# Altium schematic sheet MCU.SchDoc(MCU)
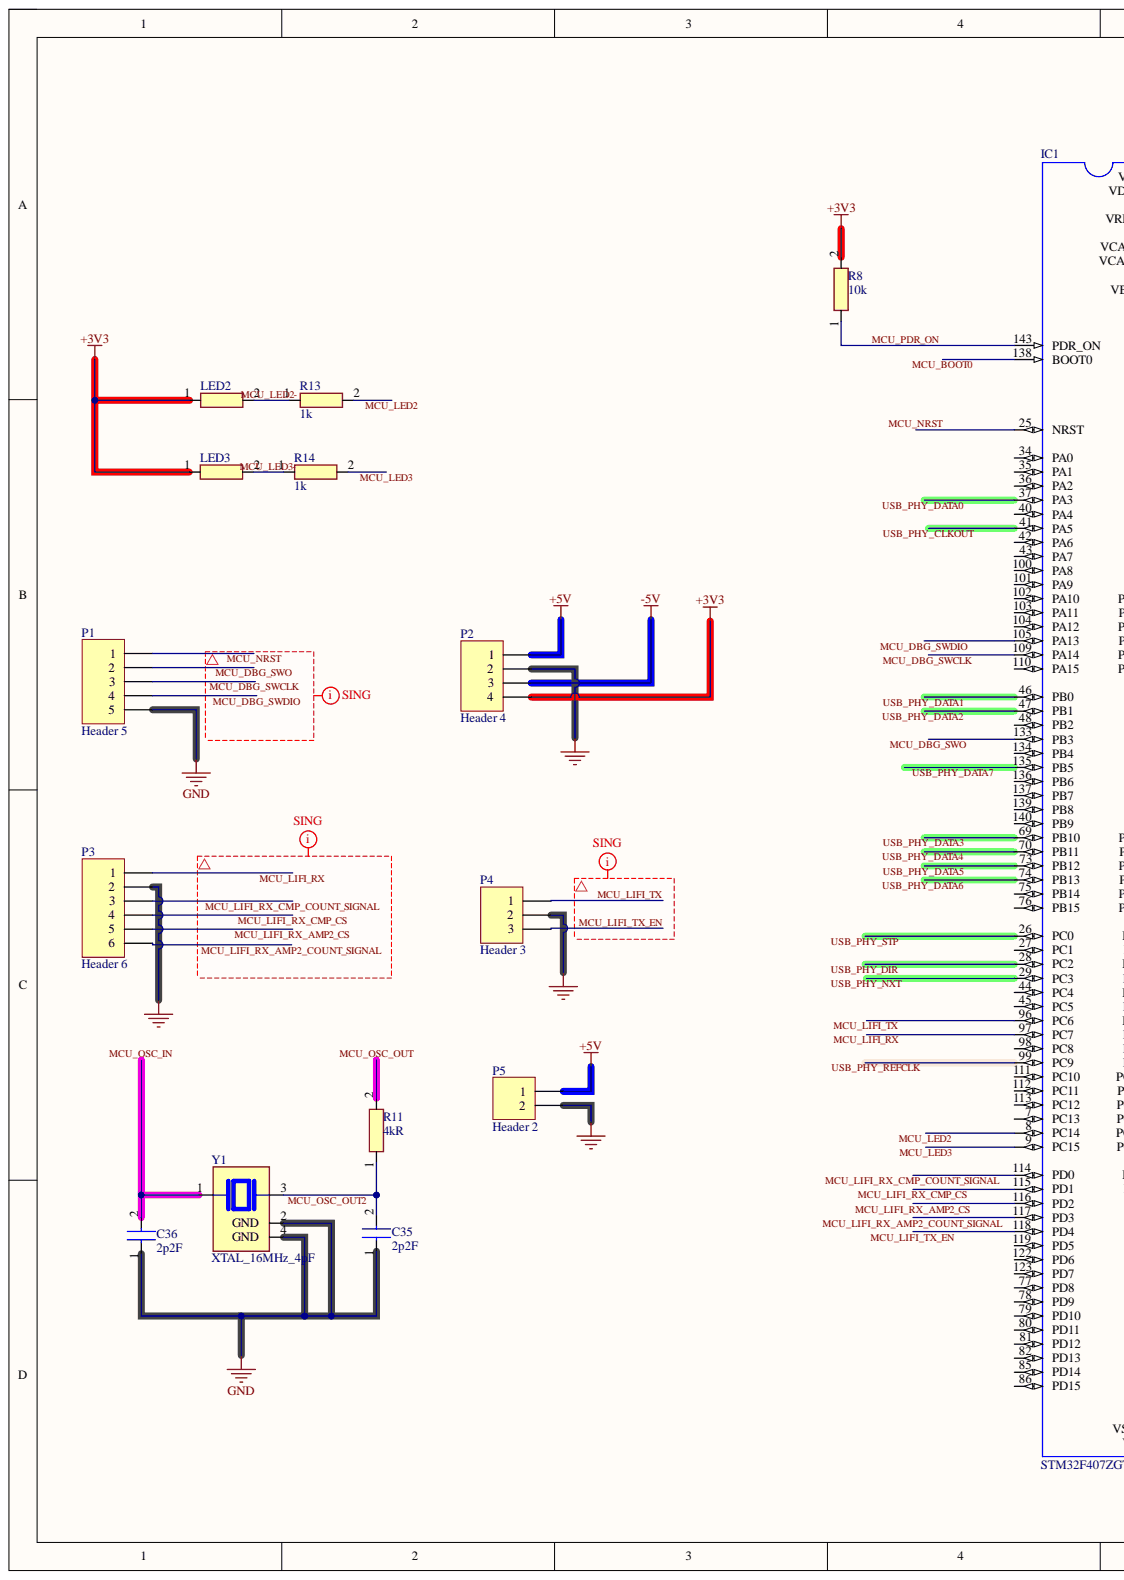
[diagram p0: MCU.SchDoc, left]
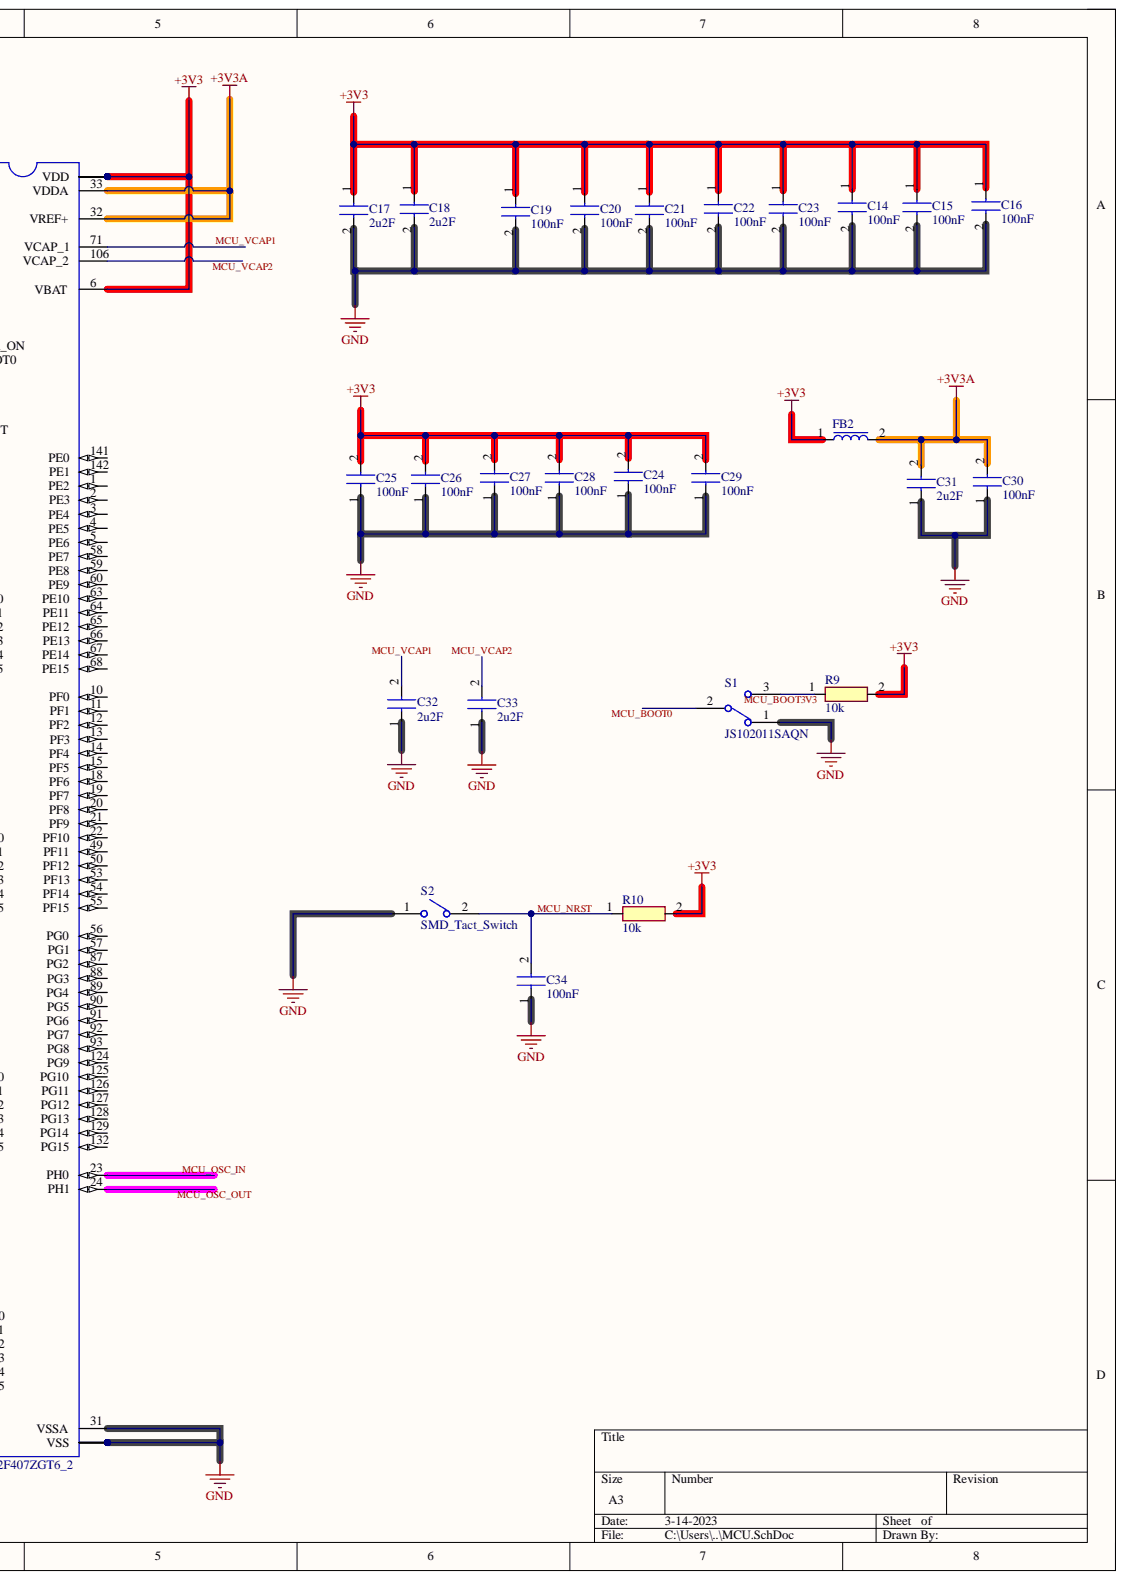
[diagram p1: MCU.SchDoc, right]

## Parts
C14  100nF  [0603C]
C15  100nF  [0603C]
C16  100nF  [0603C]
C17  2u2F  [0603C]
C18  2u2F  [0603C]
C19  100nF  [0603C]
C20  100nF  [0603C]
C21  100nF  [0603C]
C22  100nF  [0603C]
C23  100nF  [0603C]
C24  100nF  [0603C]
C25  100nF  [0603C]
C26  100nF  [0603C]
C27  100nF  [0603C]
C28  100nF  [0603C]
C29  100nF  [0603C]
C30  100nF  [0603C]
C31  2u2F  [0603C]
C32  2u2F  [0603C]
C33  2u2F  [0603C]
C34  100nF  [0603C]
C35  2p2F  [0603C]
C36  2p2F  [0603C]
FB2  Ferrite Bead @100R  [BEADC1608X90N]  (value not drawn)
IC1  STM32F407ZGT6_2  [STM32F407ZGT6]
LED2  1k  [0603R]  (value not drawn)
LED3  1k  [0603R]  (value not drawn)
P1  Header 5  [HDR1X5]
P2  Header 4  [HDR1X4]
P3  Header 6  [HDR1X6]
P4  Header 3  [HDR1X3]
P5  Header 2  [HDR1X2]
R8  10k  [0603R]
R9  10k  [0603R]
R10  10k  [0603R]
R11  4kR  [0603R]
R13  1k  [0603R]
R14  1k  [0603R]
S1  JS102011SAQN  [SW_JS102011SAQN]
S2  SMD_Tact_Switch  [SMD_Tact_Switch]
Y1  XTAL_16MHz_4pF  [XTAL_16.0000MHZ_4PF]

## Nets
+3V3: C14.1 C15.1 C16.1 C17.1 C18.1 C19.1 C20.1 C21.1 C22.1 C23.1 C24.2 C25.2 C26.2 C27.2 C28.2 C29.2 FB2.1 IC1.6(VBAT) IC1.17(VDD) IC1.30 IC1.39 IC1.52 IC1.62 IC1.72 IC1.84 IC1.95 IC1.108 IC1.121 IC1.131 IC1.144 LED2.1 LED3.1 P2.4 R8.2 R9.2 R10.2
+3V3A: C30.2 C31.2 FB2.2 IC1.32(VREF+) IC1.33(VDDA)
+5V: P2.1 P5.1
-5V: P2.3
GND: C14.2 C15.2 C16.2 C17.2 C18.2 C19.2 C20.2 C21.2 C22.2 C23.2 C24.1 C25.1 C26.1 C27.1 C28.1 C29.1 C30.1 C31.1 C32.1 C33.1 C34.1 C35.1 C36.1 IC1.16(VSS) IC1.31(VSSA) IC1.38 IC1.51 IC1.61 IC1.83 IC1.94 IC1.107 IC1.120 IC1.130 P1.5 P2.2 P3.2 P4.2 P5.2 S1.1 S2.1 Y1.2(GND) Y1.4(GND)
MCU_BOOT0: IC1.138(BOOT0) S1.2
MCU_BOOT3V3: R9.1 S1.3
MCU_DBG_SWCLK: IC1.109(PA14) P1.3
MCU_DBG_SWDIO: IC1.105(PA13) P1.4
MCU_DBG_SWO: IC1.133(PB3) P1.2
MCU_LED2: IC1.8(PC14) R13.2
MCU_LED2-: LED2.2 R13.1
MCU_LED3: IC1.9(PC15) R14.2
MCU_LED3-: LED3.2 R14.1
MCU_LIFI_RX: IC1.97(PC7) P3.1
MCU_LIFI_RX_AMP2_COUNT_SIGNAL: IC1.117(PD3) P3.6
MCU_LIFI_RX_AMP2_CS: IC1.116(PD2) P3.5
MCU_LIFI_RX_CMP_COUNT_SIGNAL: IC1.114(PD0) P3.3
MCU_LIFI_RX_CMP_CS: IC1.115(PD1) P3.4
MCU_LIFI_TX: IC1.96(PC6) P4.1
MCU_LIFI_TX_EN: IC1.118(PD4) P4.3
MCU_NRST: C34.2 IC1.25(NRST) P1.1 R10.1 S2.2
MCU_OSC_IN: C36.2 IC1.23(PH0) Y1.1
MCU_OSC_OUT: IC1.24(PH1) R11.2
MCU_OSC_OUT2: C35.2 R11.1 Y1.3
MCU_PDR_ON: IC1.143(PDR_ON) R8.1
MCU_VCAP1: C32.2 IC1.71(VCAP_1)
MCU_VCAP2: C33.2 IC1.106(VCAP_2)
USB_PHY_CLKOUT: IC1.41(PA5)
USB_PHY_DATA0: IC1.37(PA3)
USB_PHY_DATA1: IC1.46(PB0)
USB_PHY_DATA2: IC1.47(PB1)
USB_PHY_DATA3: IC1.69(PB10)
USB_PHY_DATA4: IC1.70(PB11)
USB_PHY_DATA5: IC1.73(PB12)
USB_PHY_DATA6: IC1.74(PB13)
USB_PHY_DATA7: IC1.135(PB5)
USB_PHY_DIR: IC1.28(PC2)
USB_PHY_NXT: IC1.29(PC3)
USB_PHY_REFCLK: IC1.99(PC9)
USB_PHY_STP: IC1.26(PC0)

Not connected: IC1 1(PE2) 2(PE3) 3(PE4) 4(PE5) 5(PE6) 7(PC13) 10(PF0) 11(PF1) 12(PF2) 13(PF3) 14(PF4) 15(PF5) 18(PF6) 19(PF7) 20(PF8) 21(PF9) 22(PF10) 27(PC1) 34(PA0) 35(PA1) 36(PA2) 40(PA4) 42(PA6) 43(PA7) 44(PC4) 45(PC5) 48(PB2) 49(PF11) 50(PF12) 53(PF13) 54(PF14) 55(PF15) 56(PG0) 57(PG1) 58(PE7) 59(PE8) 60(PE9) 63(PE10) 64(PE11) 65(PE12) 66(PE13) 67(PE14) 68(PE15) 75(PB14) 76(PB15) 77(PD8) 78(PD9) 79(PD10) 80(PD11) 81(PD12) 82(PD13) 85(PD14) 86(PD15) 87(PG2) 88(PG3) 89(PG4) 90(PG5) 91(PG6) 92(PG7) 93(PG8) 98(PC8) 100(PA8) 101(PA9) 102(PA10) 103(PA11) 104(PA12) 110(PA15) 111(PC10) 112(PC11) 113(PC12) 119(PD5) 122(PD6) 123(PD7) 124(PG9) 125(PG10) 126(PG11) 127(PG12) 128(PG13) 129(PG14) 132(PG15) 134(PB4) 136(PB6) 137(PB7) 139(PB8) 140(PB9) 141(PE0) 142(PE1)

## Library data of the parts
C14-C36 = 0603C: Component Kind: Standard; Library Name: LIFI_BaseStation.SchLib
FB2 = Ferrite Bead @100R: Ferrite Bead; Manufacturer_Name: KEMET; Manufacturer_Part_Number: Z0603C101BPWZT; Arrow Part Number: Z0603C101BPWZT; Arrow Price/Stock: https://www.arrow.com/en/products/z0603c101bpwzt/kemet-corporation; Component Kind: Standard; Datasheet Link: https://api.kemet.com/component-edge/download/datasheet/Z0603C101BPWZT.pdf; Height: 0.9mm; Mouser Part Number: 80-Z0603C101BPWZT; Mouser Price/Stock: https://www.mouser.co.uk/ProductDetail/KEMET/Z0603C101BPWZT?qs=BYlwlsDsB%2FqttfRwYpxQxg%3D%3D; Library Name: LIFI_BaseStation.SchLib
IC1 = STM32F407ZGT6_2: MCU 32-bit STM32F ARM Cortex M4F RISC 1024KB Flash 2.5V/3.3V 144-Pin LQFP Tray; MF: {'manufacturer': u'STMicroelectronics'}; Availability: Unavailable; Component Kind: Standard; MP: STM32F407ZGT6; Package: None; Price: None; Library Name: LIFI_BaseStation.SchLib
LED2 LED3 R8-R11 R13 R14 = 0603R: Component Kind: Standard; Library Name: LIFI_BaseStation.SchLib
P1 = Header 5: Header, 5-Pin; Component Kind: Standard; LatestRevisionDate: 17-Jul-2002; LatestRevisionNote: Re-released for DXP Platform.; Library Name: Miscellaneous Connectors.IntLib; Signal Integrity: Connector; Publisher: Altium Limited
P2 = Header 4: Header, 4-Pin; Component Kind: Standard; LatestRevisionDate: 17-Jul-2002; LatestRevisionNote: Re-released for DXP Platform.; Library Name: Miscellaneous Connectors.IntLib; Signal Integrity: Connector; Publisher: Altium Limited
P3 = Header 6: Header, 6-Pin; Component Kind: Standard; LatestRevisionDate: 17-Jul-2002; LatestRevisionNote: Re-released for DXP Platform.; Library Name: Miscellaneous Connectors.IntLib; Signal Integrity: Connector; Publisher: Altium Limited
P4 = Header 3: Header, 3-Pin; Component Kind: Standard; LatestRevisionDate: 17-Jul-2002; LatestRevisionNote: Re-released for DXP Platform.; Library Name: Miscellaneous Connectors.IntLib; Signal Integrity: Connector; Publisher: Altium Limited
P5 = Header 2: Header, 2-Pin; Component Kind: Standard; LatestRevisionDate: 17-Jul-2002; LatestRevisionNote: Re-released for DXP Platform.; Library Name: Miscellaneous Connectors.IntLib; Signal Integrity: Connector; Publisher: Altium Limited
S1 = JS102011SAQN: Slide Switch SPDT Surface Mount, Right Angle; MF: C&K; Availability: Unavailable; Component Kind: Standard; MP: JS102011SAQN; Package: None; Price: None; SnapEDA_Link: https://www.snapeda.com/parts/JS102011SAQN/C%26K/view-part/214325/?ref=snap; Library Name: LIFI_BaseStation.SchLib
S2 = SMD_Tact_Switch: SMD Tactile Switch, 3x6x2.5mm; Component Kind: Standard; Library Name: LIFI_BaseStation.SchLib
Y1 = XTAL_16MHz_4pF: ABM10W-16.0000MHZ-4-D1X-T3; MF: {'manufacturer': u'Abracon LLC'}; Availability: Unavailable; Component Kind: Standard; MP: ABM10W-16.0000MHZ-4-D1X-T3; Package: None; Price: None; Library Name: LIFI_BaseStation.SchLib
